# Altium schematic sheet uSimar_PCB_V0.SchDoc
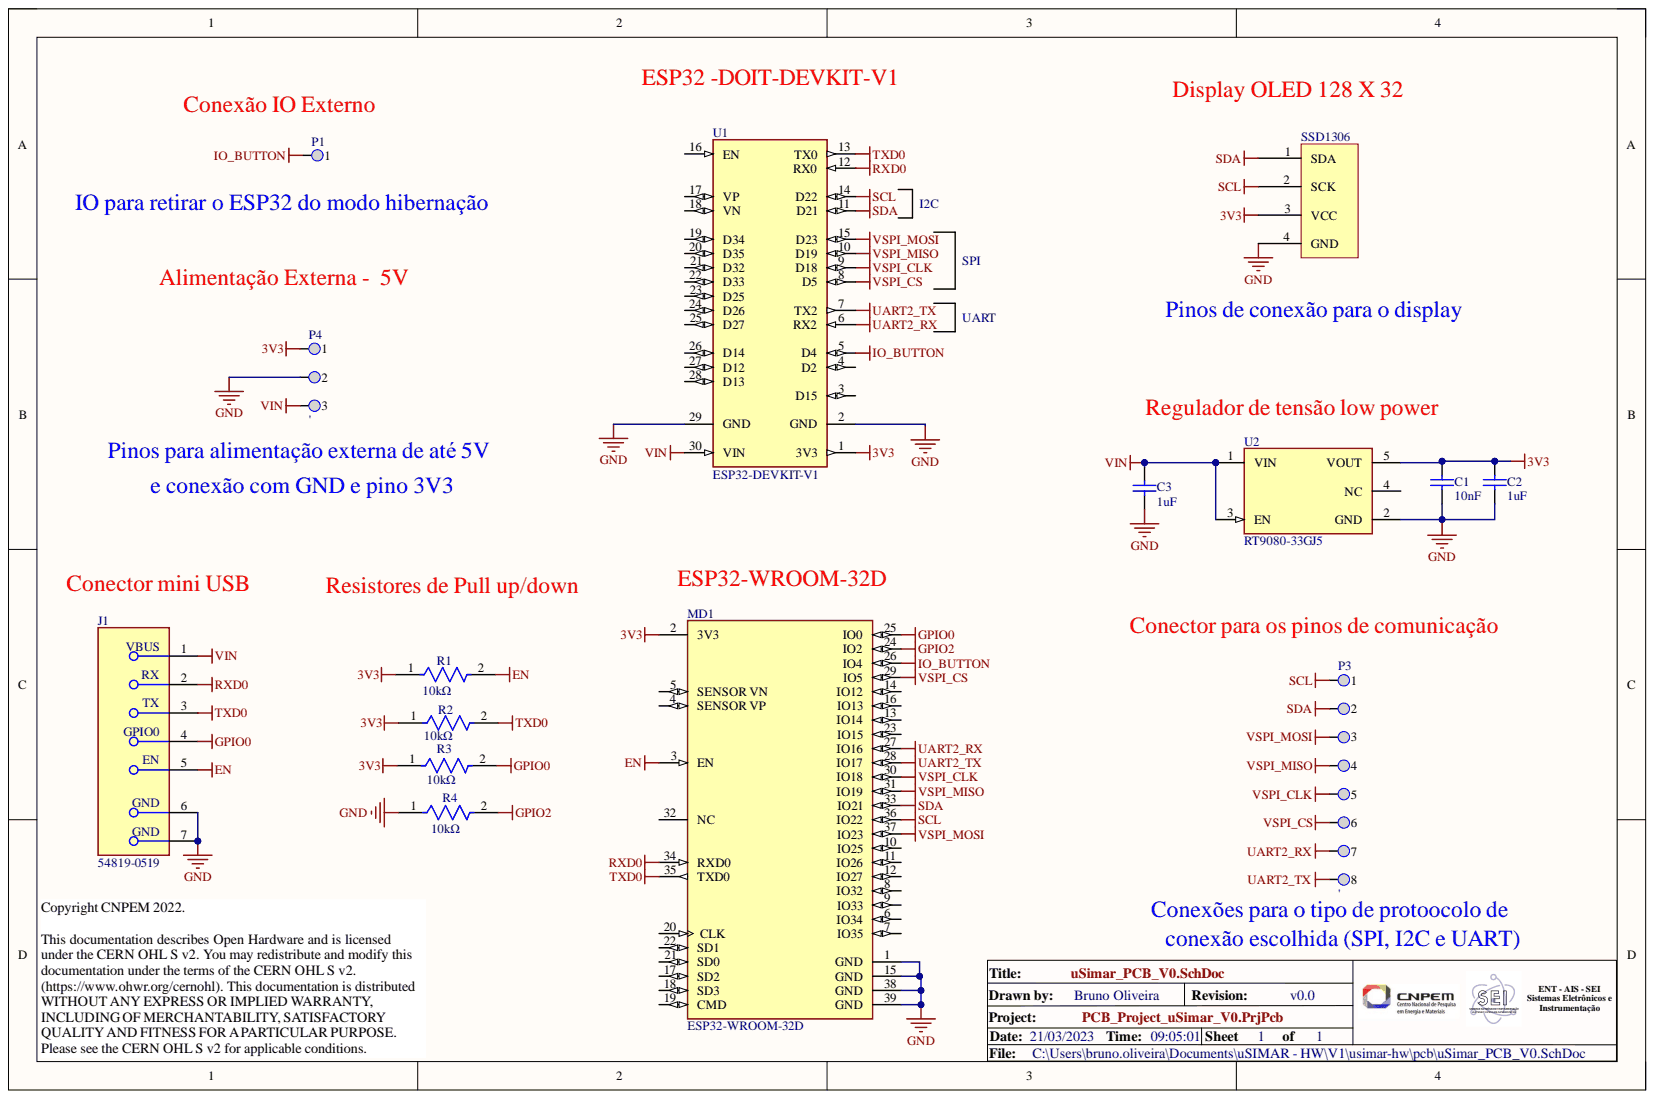
[diagram p0: uSimar_PCB_V0.SchDoc]

## Parts
C1  10nF  [C_0805]
C2  1uF  [C_0805]
C3  1uF  [C_0805]
J1  54819-0519  [FP-54819-0519-MFG]
MD1  ESP32-WROOM-32D  [FP-ESP32-WROOM-32D-MFG]
P1  '  [HDR1X1]  (value not drawn)
P3  '  [HDR1X8]
P4  '  [HDR1X3]
R1  10kΩ  [FP-CRCW0805-e3-IPC_C]
R2  10kΩ  [FP-CRCW0805-e3-IPC_C]
R3  10kΩ  [FP-CRCW0805-e3-IPC_C]
R4  10kΩ  [FP-CRCW0805-e3-IPC_C]
SSD1306  SSD1306  [SSD1306 128X32_wiyhout top opverlay]
U1  ESP32-DEVKIT-V1  [MODULE_ESP32_DEVKIT_V1]
U2  RT9080-33GJ5  [FP-RT9080-33GJ5-IPC_B]

## Nets
3V3: C1.2 C2.2 MD1.2(3V3) P4.1 R1.1 R2.1 R3.1 SSD1306.3(VCC) U1.1(3V3) U2.5(VOUT)
EN: J1.5 MD1.3(EN) R1.2
GND: C1.1 C2.1 C3.1 J1.6 J1.7 MD1.1(GND) MD1.15(GND) MD1.38(GND) MD1.39(GND) P4.2 R4.1 SSD1306.4(GND) U1.2(GND) U1.29(GND) U2.2(GND)
GPIO0: J1.4 MD1.25(IO0) R3.2
GPIO2: MD1.24(IO2) R4.2
IO_BUTTON: MD1.26(IO4) P1.1 U1.5(D4)
RXD0: J1.2 MD1.34(RXD0) U1.12(RX0)
SCL: MD1.36(IO22) P3.1 SSD1306.2(SCK) U1.14(D22)
SDA: MD1.33(IO21) P3.2 SSD1306.1(SDA) U1.11(D21)
TXD0: J1.3 MD1.35(TXD0) R2.2 U1.13(TX0)
UART2_RX: MD1.27(IO16) P3.7 U1.6(RX2)
UART2_TX: MD1.28(IO17) P3.8 U1.7(TX2)
VIN: C3.2 J1.1 P4.3 U1.30(VIN) U2.1(VIN) U2.3(EN)
VSPI_CLK: MD1.30(IO18) P3.5 U1.9(D18)
VSPI_CS: MD1.29(IO5) P3.6 U1.8(D5)
VSPI_MISO: MD1.31(IO19) P3.4 U1.10(D19)
VSPI_MOSI: MD1.37(IO23) P3.3 U1.15(D23)

Not connected: MD1 4(SENSOR_VP) 5(SENSOR_VN) 6(IO34) 7(IO35) 8(IO32) 9(IO33) 10(IO25) 11(IO26) 12(IO27) 13(IO14) 14(IO12) 16(IO13) 17(SD2) 18(SD3) 19(CMD) 20(CLK) 21(SD0) 22(SD1) 23(IO15) 32(NC); U1 3(D15) 4(D2) 16(EN) 17(VP) 18(VN) 19(D34) 20(D35) 21(D32) 22(D33) 23(D25) 24(D26) 25(D27) 26(D14) 27(D12) 28(D13); U2 4(NC)

## Library data of the parts
C1 = C0805C103K2RACTU: 0805 C 10nF Ceramic Multilayer Capacitor; 200 VDC; +125degC; X7R Dielec; +/-10%; Manufacturer: KEMET; Dissipation Factor: 2.5%; Tolerance: 10%; Voltage: 200V; Case Code (Imperial): 0805; Case Code (Metric): 2012; Case/Package: 0805; Category: Ceramic Capacitors; Component Kind: Standard; ComponentLink1Description: https://datasheet.ciiva.com/22700/1851736-22700517.pdf?src-supplier=Element14; ComponentLink1URL: https://datasheet.ciiva.com/22700/1851736-22700517.pdf?src-supplier=Element14; ComponentLink2Description: https://datasheet.ciiva.com/28672/1851736-28672827.pdf?src-supplier=Element14; ComponentLink2URL: https://datasheet.ciiva.com/28672/1851736-28672827.pdf?src-supplier=Element14; Construction: Flat; Depth: 1.25mm; Dielectric: X7R; Dielectric Material: Ceramic; Height: 0.78mm; Lead Pitch: 0.75mm; Length: 2mm; Manufacturer Part Number: C0805C103K2RACTU; Max Operating Temperature: 125°C; Min Operating Temperature: -55°C; Mounting Technology: Surface Mount; Package Quantity: 4000; Packaging: Tape and Reel; Pins: 2; Pricing: 4000=0,06100 (GBP); Radiation Hardening: No; Reach SVHC Compliant: Yes; RoHS: Yes; RoHS Compliant: Yes; Series: SMD Comm X7R; Stock: 83475; Supplier: Rs; Supplier Part Number: 1466276; Termination: SMD/SMT; Thickness: 0.035inch; Value: 10nF; Voltage Rating: 200V; Voltage Rating (DC): 200V; Width: 1.2mm; Library Name: uSimar_Components.SchLib
C2 C3 = C0805C105K4PACTU: Cap Ceramic 1uF 16V X5R 10% SMD 0805 85C Plastic T/R; Manufacturer: KEMET; Dissipation Factor: 5%; Case Code (Imperial): 0805; Case Code (Metric): 2012; Case/Package: 0805; Category: Ceramic Capacitors; Component Kind: Standard; ComponentLink1Description: http://uk.rs-online.com/webdocs/13da/0900766b813daa10.pdf?src-supplier=Rs; ComponentLink1URL: http://uk.rs-online.com/webdocs/13da/0900766b813daa10.pdf?src-supplier=Rs; ComponentLink2Description: http://www.farnell.com/datasheets/2745930.pdf?src-supplier=Farnell; ComponentLink2URL: http://www.farnell.com/datasheets/2745930.pdf?src-supplier=Farnell; ComponentLink3Description: http://uk.rs-online.com/webdocs/13da/0900766b813daa0f.pdf?src-supplier=Rs; ComponentLink3URL: http://uk.rs-online.com/webdocs/13da/0900766b813daa0f.pdf?src-supplier=Rs; ComponentLink4Description: http://components.arrow.com/part/dataview/43037300S8313636N7429?src-supplier=Arrow+Electronics; ComponentLink4URL: http://components.arrow.com/part/dataview/43037300S8313636N7429?src-supplier=Arrow+Electronics; ComponentLink5Description: http://www.farnell.com/datasheets/2745930.pdf?src-supplier=Newark; ComponentLink5URL: http://www.farnell.com/datasheets/2745930.pdf?src-supplier=Newark; ComponentLink6Description: https://search.kemet.com/component-edge/download/datasheet/C0805C105K4PAC.pdf?src-supplier=Allied+Electronics; ComponentLink6URL: https://search.kemet.com/component-edge/download/datasheet/C0805C105K4PAC.pdf?src-supplier=Allied+Electronics; ComponentLink7Description: http://www.farnell.com/datasheets/2745930.pdf?src-supplier=Element14; ComponentLink7URL: http://www.farnell.com/datasheets/2745930.pdf?src-supplier=Element14; ComponentLink8Description: https://www.verical.com/server-webapp/html/getDatasheet?partID=127986&src-supplier=Verical; ComponentLink8URL: https://www.verical.com/server-webapp/html/getDatasheet?partID=127986&src-supplier=Verical; ComponentLink9Description: https://search.kemet.com/component-edge/download/datasheet/C0805C105K4PAC.pdf?src-supplier=Mouser; ComponentLink9URL: https://search.kemet.com/component-edge/download/datasheet/C0805C105K4PAC.pdf?src-supplier=Mouser; ComponentLink10Description: https://api.kemet.com/component-edge/download/datasheet/C0805C105K4PAC.pdf?src-supplier=Digi-Key; ComponentLink10URL: https://api.kemet.com/component-edge/download/datasheet/C0805C105K4PAC.pdf?src-supplier=Digi-Key; ComponentLink11Description: https://search.kemet.com/component-edge/download/datasheet/C0805C105K4PAC.pdf?src-supplier=Avnet; ComponentLink11URL: https://search.kemet.com/component-edge/download/datasheet/C0805C105K4PAC.pdf?src-supplier=Avnet; ComponentLink12Description: http://www.kemet.com/Lists/ProductCatalog/Attachments/19/KEM_C1006_X5R_SMD.pdf?src-supplier=Avnet; ComponentLink12URL: http://www.kemet.com/Lists/ProductCatalog/Attachments/19/KEM_C1006_X5R_SMD.pdf?src-supplier=Avnet; ComponentLink13Description: http://www.farnell.com/datasheets/1824493.pdf?src-supplier=Newark; ComponentLink13URL: http://www.farnell.com/datasheets/1824493.pdf?src-supplier=Newark; ComponentLink14Description: https://search.kemet.com/component-edge/download/datasheet/C0805C105K4PAC.pdf?src-supplier=TTI; ComponentLink14URL: https://search.kemet.com/component-edge/download/datasheet/C0805C105K4PAC.pdf?src-supplier=TTI; Construction: Flat; Depth: 1.25mm; Dielectric: X5R; Dielectric Material: Ceramic; Height: 1.25mm; Lead Free: Lead Free; Length: 2mm; Manufacturer Part Number: C0805C105K4PACTU; Max Operating Temperature: 85°C; Min Operating Temperature: -55°C; Mounting Technology: Surface Mount,PCB; Package Quantity: 2500; Packaging: Tape and Reel; Pricing: 2500=0,03220, 5000=0,03190, 10000=0,03150, 25000=0,02930, 30000=0,02810, 50000=0,02590 (USD); Radiation Hardening: No; Reach SVHC Compliant: Yes; RoHS: Yes; RoHS Compliant: Yes; Series: C0805C; Stock: 30000; Supplier: Arrow Electronics; Supplier Part Number: C0805C105K4PACTU; Termination: SMD/SMT; Thickness: 0.055inch; Tolerance: 10%; Value: 1uF; Voltage: 16V; Voltage Rating: 16V; Voltage Rating (DC): 16V; Width: 1.2mm; Library Name: uSimar_Components.SchLib
J1 = CMP-04767-000009-1: CONN RCPT USB2.0 MINI B 5P R/A; Connector Type: USB; Contact Resistance: 50mR; Current Rating: 1A; Data Rate: 480Mbps; Gender: Receptacle; Insulation Resistance: 100MR; Number of Circuits: 5; Number of Ports: 1; Pitch: 0.8mm; Voltage Rating: 30V; Color: Black; Component Kind: Standard; Contact Material: Copper Alloy; Contact Plating: Gold; Depth: 9mm; Features: Shielded; Flammability Rating: UL94 V-0; Housing Color: Black; Housing Material: Polyamide; Lead Free: Lead Free; Length: 7.7mm; Max Current Rating: 1A; Max Operating Temperature: 50°C; Min Operating Temperature: 0°C; Mount: Through Hole; Number of Contacts: 5; Number of Positions: 5; Number of Rows: 1; Number of Terminals/Pins: 5; Orientation: Right Angle; Package Quantity: 3600; Packaging: Tray; Radiation Hardening: No; Series: 54819; Termination: Solder; USB Standard: USB 2.0; Voltage Rating (DC): 30V; Width: 6.9mm; Working Voltage: 30V; Library Name: uSimar_Components.SchLib
MD1 = CMP-194065-000003-1: WIFI MODULE 32MBITS SPI FLASH; Manufacturer: Espressif Systems; Bandwidth: 72MHz; Data Rate: 150Mbits/s; Interface: I2C,SPI,UART,Ethernet,I2S,Other; Max Frequency: 2484MHz; Max Supply Voltage: 3.6V; Min Supply Voltage: 3V; Nominal Supply Current: 500mA; Number Of Adc Channels: 16; Number Of Gpio: 32; Sensitivity: -98dBm; Automotive: No; Category: UNK; Component Kind: Standard; ComponentLink2Description: Datasheet; ComponentLink2URL: https://www.espressif.com/sites/default/files/documentation/esp32-wroom-32d_esp32-wroom-32u_datasheet_en.pdf; Device Class L1: Integrated Circuits (ICs); Device Class L2: RF Semiconductors and Devices; Device Class L3: Transceivers; Digikey Description: WIFI MODULE 32MBITS SPI FLASH; Height: 3.2mm; Lead Free: Yes; Manufacturer Part Number: ESP32-WROOM-32D; Mouser Description: Wi-Fi/BT BLE Module ESP32-D0WD, SPI Flash, UART, P; Octopart: https://octopart.com/esp32-wroom-32d-espressif+systems-91040050; Package: ESP32-WROOM-32D; RoHS: TRUE; Temperature: -40°C to +85°C; Temperature Range High: +85°C; Temperature Range Low: -40°C; Library Name: uSimar_Components.SchLib
P1 = Header 1: Header, 1-Pin; Component Kind: Standard; Library Name: uSimar_Components.SchLib
P3 = Header 8: Header, 8-Pin; Component Kind: Standard; Library Name: uSimar_Components.SchLib
P4 = Header 3: Header, 3-Pin; Component Kind: Standard; Library Name: uSimar_Components.SchLib
R1-R4 = CMP-2001-04908-2: RES Thick Film, 10kΩ, 1%, 0.125W, 100ppm/°C, 0805; Power Rating: 125mW; Resistance: 10kR; Voltage Rating: 150V; Case Code (Imperial): 0805; Case Code (Metric): 2012; Case/Package: 0805; Component Kind: Standard; Composition: Thick Film; Contact Plating: Tin; Depth: 1.25mm; Height: 0.45mm; Lead Free: Lead Free; Length: 2mm; Max Operating Temperature: 155°C; Military Standard: Not; Min Operating Temperature: -55°C; Package Quantity: 5000; Packaging: Tape and Reel; Radiation Hardening: No; Temperature Coefficient: 100ppm/°C; Termination: SMD/SMT; Tolerance: 1%; Voltage Rating (DC): 150V; Weight: 0.000194oz; Width: 1.25mm; Library Name: uSimar_Components.SchLib
U1 = ESP32-DEVKIT-V1: Dual core, Wi-Fi: 2.4 GHz up to 150 Mbits/s,BLE (Bluetooth Low Energy) and legacy Bluetooth, 32 bits, Up to 240 MHz; MF: Do it; Availability: Not in stock; Check_prices: https://www.snapeda.com/parts/ESP32-DEVKIT-V1/Do+it/view-part/?ref=eda; Component Kind: Standard; MP: ESP32-DEVKIT-V1; Package: None; Price: None; SnapEDA_Link: https://www.snapeda.com/parts/ESP32-DEVKIT-V1/Do+it/view-part/?ref=snap; Library Name: uSimar_Components.SchLib
U2 = CMP-79890-000003-1: IC REG LIN 3.3V 600MA TSOT23-5; Dropout Voltage: 530mV; Max Input Voltage: 5.5V; Number of Regulators: 1; Output Configuration: Positive; Output Type: Fixed; Power Supply Rejection Ratio (PSRR): 75dB; Quiescent Current: 4uA; Case/Package: SOT-23-5; Component Kind: Standard; Max Operating Temperature: 85°C; Min Operating Temperature: -40°C; Min Output Voltage: 3.3V; Mount: Surface Mount; Output Current: 600mA; Packaging: Cut Tape; RoHS Compliant: Yes; Library Name: uSimar_Components.SchLib
SSD1306 = SSD1306: Component Kind: Standard; Library Name: uSimar_Components.SchLib
